# Altium schematic sheet Gatekeeper_sheet8_connection_interface_V2.1.SchDoc(Gatekeeper_sheet8_connection_interface_V2.1)
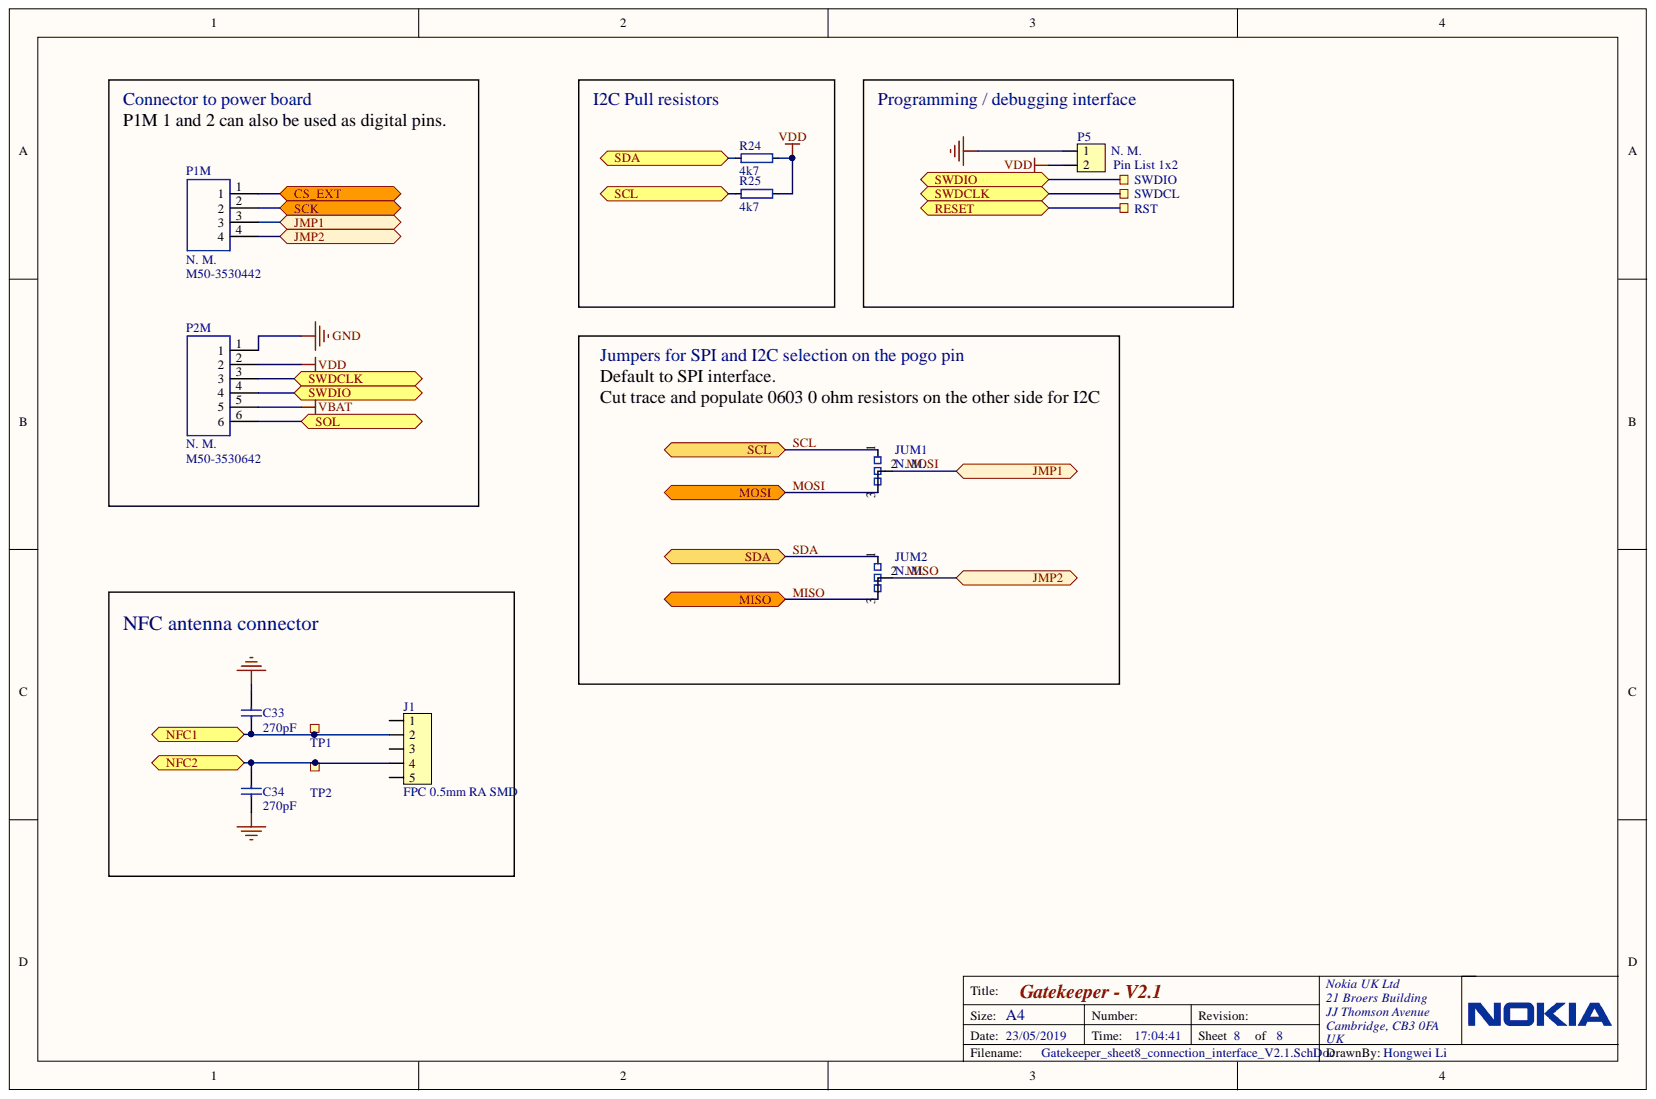
[diagram p0: Gatekeeper_sheet8_connection_interface_V2.1.SchDoc]

## Parts
C33  270pF  [CAPC1005X04L]
C34  270pF  [CAPC1005X04L]
J1  FPC 0.5mm RA SMD  [FPC_05P-0.5mm-RA-SMD]
JUM1  N. M.  [Jumper 0603 Con]  (no BOM)
JUM2  N. M.  [Jumper 0603 Con]  (no BOM)
P1M  N. M.  value M50-3530442  [HDRV4W45P0X127_1X4_508X210X430P]  (no BOM)
P2M  N. M.  value M50-3530642  [HDRV6W45P0X127_1X6_762X210X430P]  (no BOM)
P5  N. M.  value Pin List 1x2  [LIST_1x2-NC]  (no BOM)
R24  4k7  [RESC1005X04L]
R25  4k7  [RESC1005X04L]
RST  =Value  [TP_SMD_Ø1.5mm]  (no BOM)
SWDCL  =Value  [TP_SMD_Ø1.5mm]  (no BOM)
SWDIO  =Value  [TP_SMD_Ø1.5mm]  (no BOM)
TP1  =Value  [TP_SMD_Ø1.2mm]  (no BOM)
TP2  =Value  [TP_SMD_Ø1.2mm]  (no BOM)

## Ports
CS_EXT, JMP1, JMP2, MISO, MOSI, NFC1, NFC2, RESET, SCK, SCL, SDA, SOL, SWDCLK, SWDIO

## Nets
GND: C33.1 C34.1 P2M.1 P5.1
VBAT: P2M.5
VDD: P2M.2 P5.2 R24.1 R25.1
CS_EXT: P1M.1 ; port CS_EXT
MISO: JUM2.2 JUM2.3 P1M.4 ; port JMP2, port MISO
MOSI: JUM1.2 JUM1.3 P1M.3 ; port JMP1, port MOSI
NFC1: C33.2 J1.2 TP1.1 ; port NFC1
NFC2: C34.2 J1.4 TP2.1 ; port NFC2
RESET: RST.1 ; port RESET
SCK: P1M.2 ; port SCK
SCL: JUM1.1 R25.2 ; port SCL
SDA: JUM2.1 R24.2 ; port SDA
SOL: P2M.6 ; port SOL
SWDCLK: P2M.3 SWDCL.1 ; port SWDCLK
SWDIO: P2M.4 SWDIO.1 ; port SWDIO

Not connected: J1 1 3 5

## Library data of the parts
C33 C34 = CAP_0402: Capacitor, 0402, NP0, ±2%; Manufacturer Part Number: C0402C271G5GACTU; Manufacturer: KEMET; 2nd source part number: 581-04023A271GAT2A; Note: 50V; Value: 270pF; Supplier part number: 80-C0402C271G5G; Supplier: Mouser; Pin Count: 2; Footprint Doc: 0402; Datasheet: https://www.mouser.co.uk/datasheet/2/212/KEM_C1003_C0G_SMD-1101588.pdf; Component Kind: Standard; 2nd source Supplier: Mouser; Library Name: Gatekeeper_V2.1.SCHLIB
J1 = (no symbol name): 0.5mm FPC, 5P,Right Angle SMT,Double Contact,H=1.2mm,White, Tin plated; Manufacturer part number: 51281-0594; Manufacturer: Molex; 2nd source part number: WM12846CT-ND; Value: FPC 0.5mm RA SMD; Supplier Part Number: 538-51281-0594; Supplier: Mouser; Pin Count: 7; Part Number: FPC_05P-0.5mm-RA-SMD; LatestRevisionDate: 2016-04-21; Footprint Doc: FPC_05P-0.5mm-RA-SMD; Datasheet: \\hizz\pro\app9717\Datasheet\connectores\W-CON\5141-XXRZDNXX0X.pdf; Component Kind: Standard; 2nd source Supplier: DigiKey; Library Name: Gatekeeper_V2.1.SchLib
JUM1 JUM2 = (no symbol name): Jumper with one side connected, the other side can be connected with 0603 0 ohm.; Manufacturer Part Number: MCT06030Z0000ZP500; Manufacturer: Vishay; Value: 0 ohm; Assembly: Not Mounted; Supplier Part Number: 594-MCT06030Z0000ZP5; Supplier: Mouser; Footprint size: 0603; ComponentLink2Description: Supplier link; ComponentLink1Description: Datasheet; Library Name: Gatekeeper_V2.1.SchLib
P1M = M50-3530442: Headers & Wire Housings 4 WAY SIL VERT PC TAIL P/HDR; Manufacturer: HARWIN; Geometry.Height: 4.3mm; Assembly: Not Mounted; Supplier Part Number: 855-M50-3530442; Supplier: Mouser; Mouser Price/Stock: https://www.mouser.com/Search/Refine.aspx?Keyword=855-M50-3530442; Mouser Part Number: 855-M50-3530442; Manufacturer Part Number: M50-3530442; Library Name: M50-3530x42.SchLib; Datasheet Link: https://componentsearchengine.com/Datasheets/1/M50-3530442.pdf
P2M = M50-3530642: Headers & Wire Housings 6 WAY SIL VERT PC TAIL P/HDR; Manufacturer: HARWIN; Geometry.Height: 4.3mm; Assembly: Not Mounted; Supplier Part Number: 855-M50-3530642; Supplier: Mouser; Mouser Price/Stock: https://www.mouser.com/Search/Refine.aspx?Keyword=855-M50-3530642; Mouser Part Number: 855-M50-3530642; Manufacturer Part Number: M50-3530642; Library Name: M50-3530x42.SchLib; Datasheet Link: https://componentsearchengine.com/Datasheets/1/M50-3530642.pdf
P5 = (no symbol name): Pin List 1x2, 2.54mm (100mil); Part Number: Pin List 1x2, N.C.; Assembly: Not Mounted; Value: Pin List 1x2; Pin Count: 2; Footprint Doc: LIST_1x2; Library Name: Gatekeeper_V2.1.SchLib
R24 R25 = (no symbol name): Resistor, 0402, ±1%, 0.063W; Part Number: R0402; Manufacturer Part Number: CRCW04024K70FKEDC; Manufacturer: Vishay; 2nd source part number: AC0402FR-074K7L; Value Ohm: 4700; Note: Chip Res 1%; Value: 4k7; Supplier part number: 71-CRCW04024K70FKEDC; Supplier: Mouser; Pin Count: 2; Footprint Doc: 0402; Datasheet: https://www.mouser.co.uk/datasheet/2/427/crcwce3-1223726.pdf; Component Kind: Standard; 2nd source Supplier: Mouser; Library Name: Gatekeeper.SCHLIB
RST SWDCL SWDIO TP1 TP2 = TP_SMD: SMD testpad of various size; Library Name: Gatekeeper_V2.1.SchLib
